# Altium schematic sheet Remote controller 1023.SchDoc
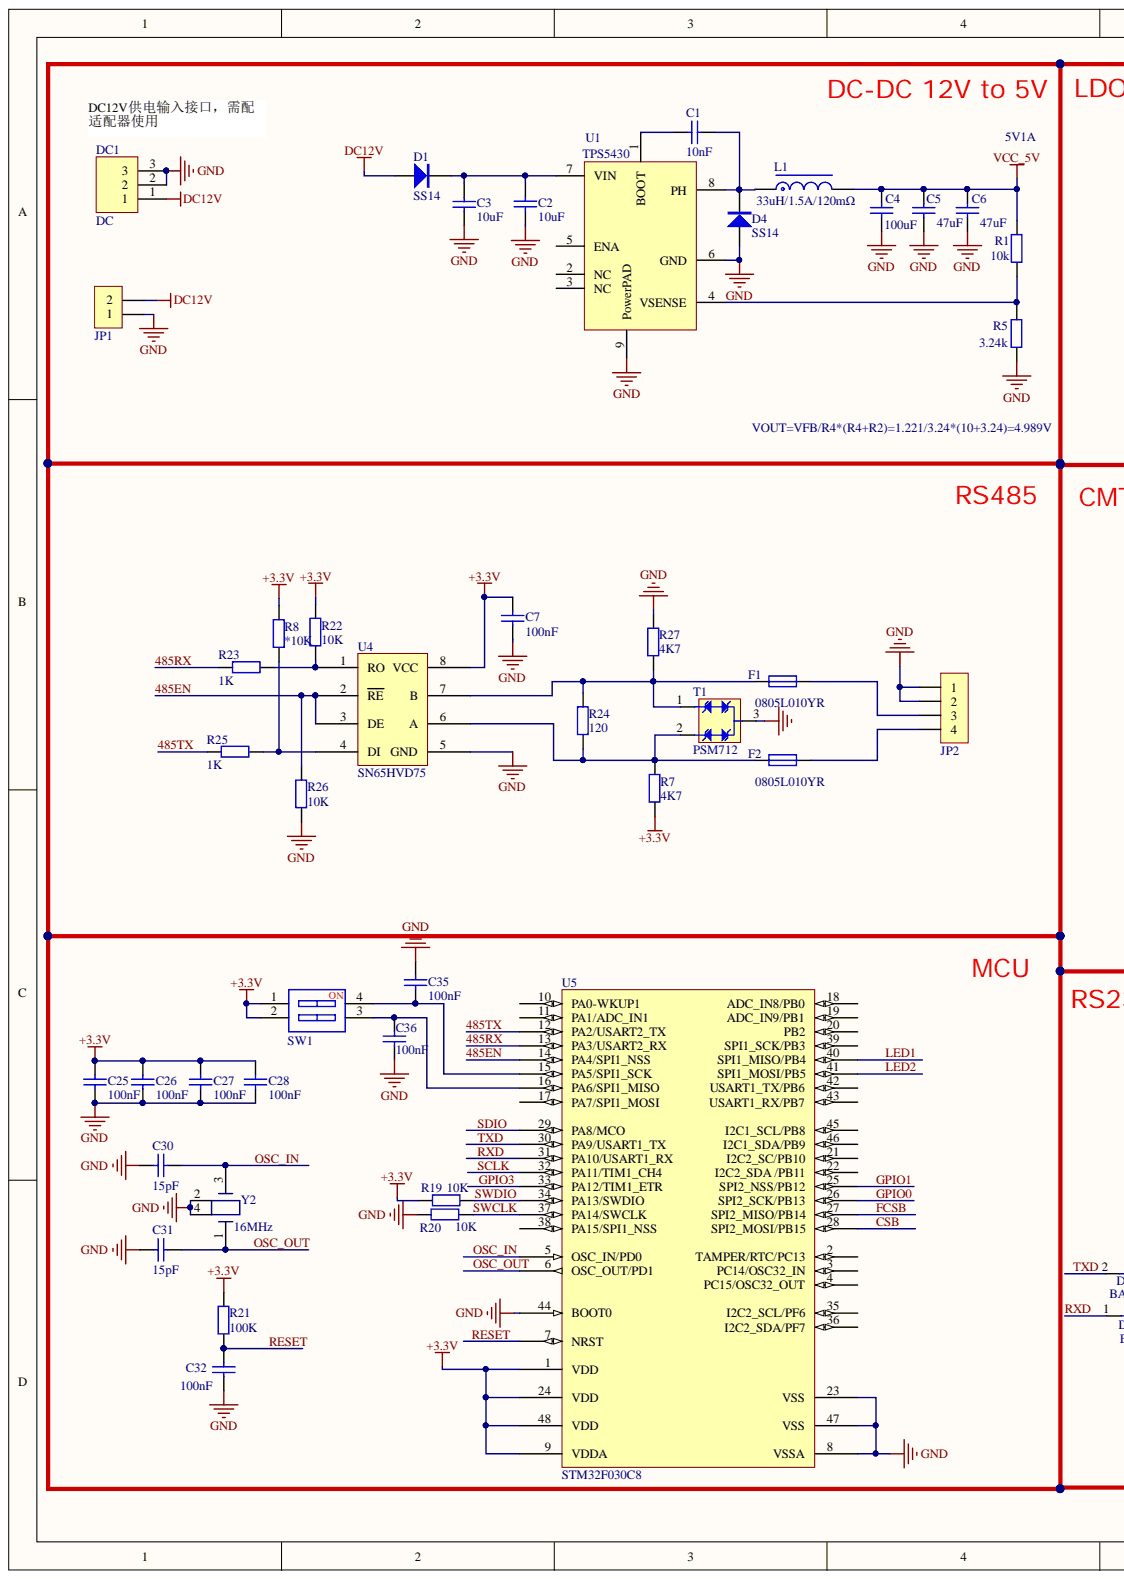
[diagram p0: Remote controller 1023.SchDoc, left]
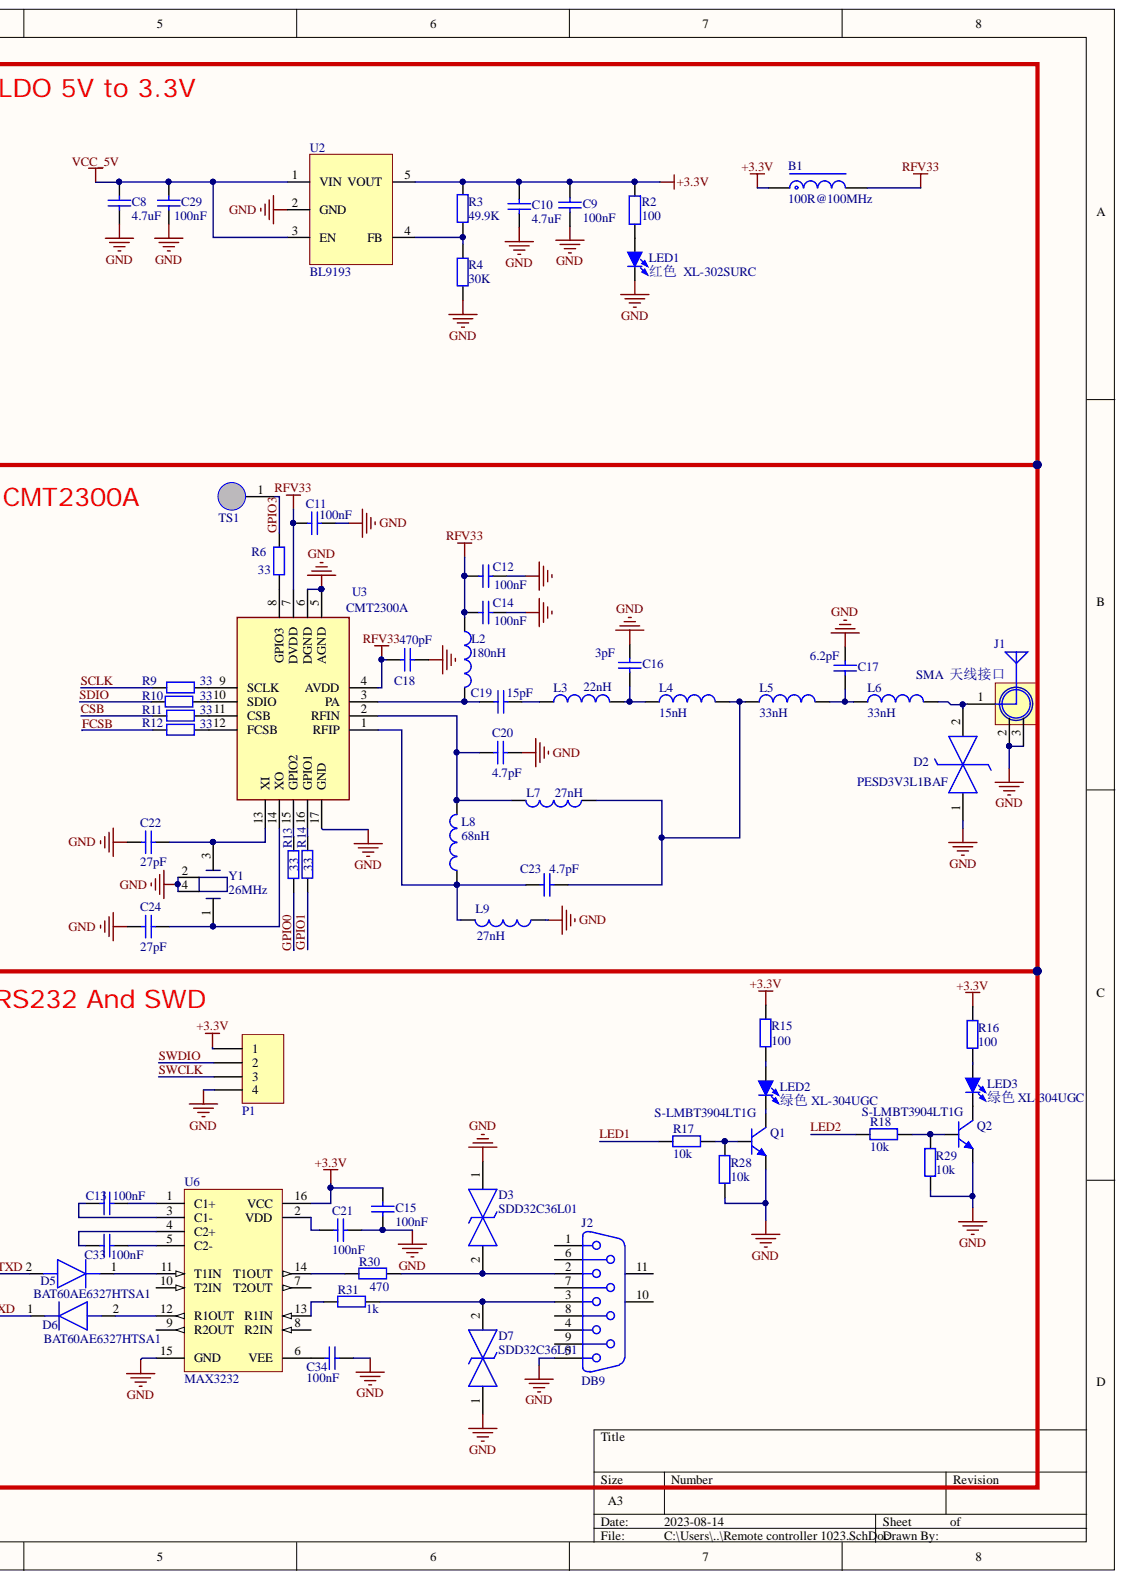
[diagram p1: Remote controller 1023.SchDoc, right]

## Parts
B1  100R@100MHz  [C 0603]
C1  10nF  [C 0603]
C2  10uF  [C1206_M]
C3  10uF  [C1206_M]
C4  100uF  [C1210_M]
C5  47uF  [C1210_M]
C6  47uF  [C1210_M]
C7  100nF  [C 0603]
C8  4.7uF  [C 0603]
C9  100nF  [C 0603]
C10  4.7uF  [C 0603]
C11  100nF  [C 0603]
C12  100nF  [C 0603]
C13  100nF  [C 0603]
C14  100nF  [C 0603]
C15  100nF  [C 0603]
C16  3pF  [C 0603]
C17  6.2pF  [C 0603]
C18  470pF  [C 0603]
C19  15pF  [C 0603]
C20  4.7pF  [C 0603]
C21  100nF  [C 0603]
C22  27pF  [C 0603]
C23  4.7pF  [C 0603]
C24  27pF  [C 0603]
C25  100nF  [C 0603]
C26  100nF  [C 0603]
C27  100nF  [C 0603]
C28  100nF  [C 0603]
C29  100nF  [C 0603]
C30  15pF  [C 0603]
C31  15pF  [C 0603]
C32  100nF  [C 0603]
C33  100nF  [C 0603]
C34  100nF  [C 0603]
C35  100nF  [C 0603]
C36  100nF  [C 0603]
D1  SS14  [SMA]
D2  PESD3V3L1BAF  [SOD2512X110N]
D3  SDD32C36L01  [SOD2612X120N]
D4  SS14  [SMA]
D5  BAT60AE6327HTSA1  [SOD2513X110N]
D6  BAT60AE6327HTSA1  [SOD2513X110N]
D7  SDD32C36L01  [SOD2612X120N]
DC1  DC  [DC-005]
F1  0805L010YR  [R0805_M]
F2  0805L010YR  [R0805_M]
J1  SMA  天线接口  [SMA_FR]
J2  DB9  [DB9/S_A]
JP1  HDR-1X2  [KF2EDGK5.08-WI-2P]  (value not drawn)
JP2  HDR-1X4  [KF2EDGK5.08-WI-4P]  (value not drawn)
L1  33uH/1.5A/120mΩ  [CD75]
L2  180nH  [C 0603]
L3  22nH  [C 0603]
L4  15nH  [C 0603]
L5  33nH  [C 0603]
L6  33nH  [C 0603]
L7  27nH  [C 0603]
L8  68nH  [C 0603]
L9  27nH  [C 0603]
LED1  红色  XL-302SURC  [LED 3MM-R]
LED2  绿色 XL-304UGC  [LED 3MM-G]
LED3  绿色 XL-304UGC  [LED 3MM-G]
P1  Header 4  [HDR1X4]  (value not drawn)
Q1  S-LMBT3904LT1G  [SOT23-3N]
Q2  S-LMBT3904LT1G  [SOT23-3N]
R1  10k  [C 0603]
R2  100  [C 0603]
R3  49.9K  [C 0603]
R4  30K  [C 0603]
R5  3.24k  [C 0603]
R6  33  [C 0603]
R7  value 4K7  [R0603_M]  (hidden comment: 1k)
R8  value *10K  [R0603_M]  (hidden comment: 1k)
R9  33  [C 0603]
R10  33  [C 0603]
R11  33  [C 0603]
R12  33  [C 0603]
R13  33  [C 0603]
R14  33  [C 0603]
R15  100  [C 0603]
R16  100  [C 0603]
R17  10k  [C 0603]
R18  10k  [C 0603]
R19  10K  [C 0603]
R20  10K  [C 0603]
R21  100K  [C 0603]
R22  value 10K  [R0603_M]  (hidden comment: 1k)
R23  1k  [R0603_M]
R24  value 120  [R0603_M]  (hidden comment: 1k)
R25  1k  [R0603_M]
R26  value 10K  [R0603_M]  (hidden comment: 1k)
R27  value 4K7  [R0603_M]  (hidden comment: 1k)
R28  10k  [C 0603]
R29  10k  [C 0603]
R30  470  [C 0603]
R31  1k  [C 0603]
SW1  DIP-SW-2P  [SW DIP-2P]  (value not drawn)
T1  PSM712  [SOT23-3M]
TS1  12x16  [TEST 1.2]  (value not drawn)
U1  TPS5430  [TPS5430]
U2  BL9193  [SOT23-5 - duplicate]
U3  CMT2300A  [QFN50P300X300X80-17N-D]
U4  SN65HVD75  [SOP8W_M]
U5  STM32F030C8  [LQFP48 7X7_N]
U6  MAX3232  [SOP16_M]
Y1  26MHz  [XTAL-3225]
Y2  16MHz  [XTAL-3225]

## Nets
+3.3V: B1.1 C7.2 C9.2 C10.2 C15.2 C25.2 C26.2 C27.2 C28.2 P1.1 R2.2 R3.2 R7.1 R8.2 R15.2 R16.2 R19.1 R21.2 R22.2 SW1.1 SW1.2 U2.5(VOUT) U4.8(VCC) U5.1(VDD) U5.9(VDDA) U5.24(VDD) U5.48(VDD) U6.16(VCC)
DC12V: D1.A DC1.1 JP1.2
GND: C2.1 C3.1 C4.1 C5.1 C6.1 C7.1 C8.1 C9.1 C10.1 C11.2 C12.1 C14.1 C15.1 C16.1 C17.1 C18.1 C20.1 C21.2 C22.2 C24.2 C25.1 C26.1 C27.1 C28.1 C29.1 C30.2 C31.2 C32.1 C34.1 C35.1 C36.1 D2.1 D3.1 D4.A D7.1 DC1.2 DC1.3 J1.2 J1.3 J2.5 JP1.1 JP2.1 JP2.2 L9.1 LED1.2 P1.4 Q1.2 Q2.2 R4.1 R5.1 R20.1 R26.1 R27.2 R28.2 R29.2 T1.3 U1.6(GND) U1.9(PowerPAD) U2.2(GND) U3.5(AGND) U3.6(DGND) U3.17(GND) U4.5(GND) U5.8(VSSA) U5.23(VSS) U5.44(BOOT0) U5.47(VSS) U6.15(GND) Y1.2 Y1.4 Y2.2 Y2.4
RFV33: B1.2 C11.1 C12.2 C14.2 C18.2 L2.1 U3.4(AVDD) U3.7(DVDD)
VCC_5V: C4.2 C5.2 C6.2 C8.2 C29.2 L1.2 R1.1 U2.1(VIN) U2.3(EN)
485EN: R26.2 U4.2(RE) U4.3(DE) U5.14(PA4/SPI1_NSS)
485RX: R23.1 U5.13(PA3/USART2_RX)
485TX: R25.1 U5.12(PA2/USART2_TX)
CSB: R11.1 U5.28(SPI2_MOSI/PB15)
FCSB: R12.1 U5.27(SPI2_MISO/PB14)
GPIO0: R13.2 U5.26(SPI2_SCK/PB13)
GPIO1: R14.2 U5.25(SPI2_NSS/PB12)
GPIO3: R6.1 TS1.1 U5.33(PA12/TIM1_ETR)
LED1: R17.2 U5.40(SPI1_MISO/PB4)
LED2: R18.2 U5.41(SPI1_MOSI/PB5)
OSC_IN: C30.1 U5.5(OSC_IN/PD0) Y2.3
OSC_OUT: C31.1 U5.6(OSC_OUT/PD1) Y2.1
RESET: C32.2 R21.1 U5.7(NRST)
RXD: D6.1 U5.31(PA10/USART1_RX)
SCLK: R9.1 U5.32(PA11/TIM1_CH4)
SDIO: R10.1 U5.29(PA8/MCO)
SWCLK: P1.3 R20.2 U5.37(PA14/SWCLK)
SWDIO: P1.2 R19.2 U5.34(PA13/SWDIO)
TXD: D5.2 U5.30(PA9/USART1_TX)
NetC1_1: C1.1 D4.K L1.1 U1.8(PH)
NetC1_2: C1.2 U1.1(BOOT)
NetC2_2: C2.2 C3.2 D1.K U1.7(VIN)
NetC13_1: C13.1 U6.1(C1+)
NetC13_2: C13.2 U6.3(C1-)
NetC16_2: C16.2 L3.2 L4.1
NetC17_2: C17.2 L5.2 L6.1
NetC19_1: C19.1 L3.1
NetC19_2: C19.2 L2.2 U3.3(PA)
NetC20_2: C20.2 L7.2 L8.2 U3.2(RFIN)
NetC21_1: C21.1 U6.2(VDD)
NetC22_1: C22.1 U3.13(XI) Y1.3
NetC23_1: C23.1 L4.2 L5.1 L7.1
NetC23_2: C23.2 L8.1 L9.2 U3.1(RFIP)
NetC24_1: C24.1 U3.14(XO) Y1.1
NetC33_1: C33.1 U6.5(C2-)
NetC33_2: C33.2 U6.4(C2+)
NetC34_2: C34.2 U6.6(VEE)
NetC35_2: C35.2 SW1.4 U5.15(PA5/SPI1_SCK)
NetC36_2: C36.2 SW1.3 U5.16(PA6/SPI1_MISO)
NetD2_2: D2.2 J1.1 L6.2
NetD3_2: D3.2 J2.2 R30.1
NetD5_1: D5.1 U6.11(T1IN)
NetD6_2: D6.2 U6.12(R1OUT)
NetD7_2: D7.2 J2.3 R31.1
NetF1_1: F1.1 R24.2 R27.1 T1.1 U4.7(B)
NetF1_2: F1.2 JP2.3
NetF2_1: F2.1 R7.2 R24.1 T1.2 U4.6(A)
NetF2_2: F2.2 JP2.4
NetLED1_1: LED1.1 R2.1
NetLED2_1: LED2.1 R15.1
NetLED2_2: LED2.2 Q1.3
NetLED3_1: LED3.1 R16.1
NetLED3_2: LED3.2 Q2.3
NetQ1_1: Q1.1 R17.1 R28.1
NetQ2_1: Q2.1 R18.1 R29.1
NetR1_2: R1.2 R5.2 U1.4(VSENSE)
NetR3_1: R3.1 R4.2 U2.4(FB)
NetR6_2: R6.2 U3.8(GPIO3)
NetR8_1: R8.1 R25.2 U4.4(DI)
NetR9_2: R9.2 U3.9(SCLK)
NetR10_2: R10.2 U3.10(SDIO)
NetR11_2: R11.2 U3.11(CSB)
NetR12_2: R12.2 U3.12(FCSB)
NetR13_1: R13.1 U3.15(GPIO2)
NetR14_1: R14.1 U3.16(GPIO1)
NetR22_1: R22.1 R23.2 U4.1(RO)
NetR30_2: R30.2 U6.14(T1OUT)
NetR31_2: R31.2 U6.13(R1IN)
N00014:

Not connected: J2 1 4 6 7 8 9 10 11; U1 2(NC) 3(NC) 5(ENA); U5 2(TAMPER/RTC/PC13) 3(PC14/OSC32_IN) 4(PC15/OSC32_OUT) 10(PA0-WKUP1) 11(PA1/ADC_IN1) 17(PA7/SPI1_MOSI) 18(ADC_IN8/PB0) 19(ADC_IN9/PB1) 20(PB2) 21(I2C2_SC/PB10) 22(I2C2_SDA_/PB11) 35(I2C2_SCL/PF6) 36(I2C2_SDA/PF7) 38(PA15/SPI1_NSS) 39(SPI1_SCK/PB3) 42(USART1_TX/PB6) 43(USART1_RX/PB7) 45(I2C1_SCL/PB8) 46(I2C1_SDA/PB9); U6 7(T2OUT) 8(R2IN) 9(R2OUT) 10(T2IN)

## Library data of the parts
B1 = FB: 磁珠; BOM名称: 直插磁珠; 联系卖家，免费查找封装: 唯一出售店铺网址https://shop463601444.taobao.com; Component Kind: Standard; Library Name: 0.1 - 电阻-电容-电感-晶振-晶体-磁珠-电位器-法拉电容-馈通电容.SchLib
C1-C7 C9 C11-C36 = Cap: 电容; Component Kind: Standard; Library Name: Schlib.SchLib
C8 C10 = CAP 0402: Component Kind: Standard; Library Name: Schlib.SchLib
D1 D4 = SS14: 肖特基二极管; 属性: 40V/1A; 版权归属: 源创客; BOM名称: 肖特基二极管; Component Kind: Standard; Library Name: 二极管-整流桥.SchLib; 学习资源: www.ooocke.com
D2 = PESD5V0S1BA,115: Diode ESD (Bi-directional); Manufacturer_Name: Nexperia; Arrow Part Number: PESD5V0S1BA,115; Arrow Price/Stock: https://www.arrow.com/en/products/pesd5v0s1ba115/nexperia?region=europe; Component Kind: Standard; Datasheet Link: https://assets.nexperia.com/documents/data-sheet/PESD5V0S1BA.pdf; Height: 1.1mm; Manufacturer_Part_Number: PESD5V0S1BA,115; Mouser Part Number: 771-PESD5V0S1BA-T/R; Mouser Price/Stock: https://www.mouser.co.uk/ProductDetail/Nexperia/PESD5V0S1BA115?qs=LOCUfHb8d9sN8BP26arEXQ%3D%3D
D3 D7 = SDD32C36L01: TVS Diode (Bi-directional); Manufacturer_Name: KEMET; Component Kind: Standard; Datasheet Link: https://www.yageo.com/upload/media/product/productsearch/datasheet/cpc/esd/SDD32CXXL01_1.pdf; Height: 1.2mm; Manufacturer_Part_Number: SDD32C36L01
D5 D6 = BAT60AE6327HTSA1: Diode; Manufacturer_Name: Infineon; Arrow Part Number: BAT60AE6327HTSA1; Arrow Price/Stock: https://www.arrow.com/en/products/bat60ae6327htsa1/infineon-technologies-ag?region=nac; Component Kind: Standard; Datasheet Link: https://www.infineon.com/dgdl/Infineon-BAT60ASERIES-DS-v01_01-en.pdf?fileId=db3a304313d846880113def70c9304a9; Height: 1.1mm; Manufacturer_Part_Number: BAT60AE6327HTSA1; Mouser Part Number: 726-BAT60AE6327HTSA1; Mouser Price/Stock: https://www.mouser.co.uk/ProductDetail/Infineon-Technologies/BAT60AE6327HTSA1?qs=OwbwYO03UsIW3Y2lCXbs8Q%3D%3D
DC1 = DC3: 3脚DC接口; Component Kind: Standard; Library Name: Schlib.SchLib
F1 F2 = FUSE: Resistor; Component Kind: Standard; LatestRevisionDate: 17-Jul-2002; LatestRevisionNote: Re-released for DXP Platform.; Library Name: Sch.SchLib; PackageDescription: Axial Device, Thru-Hole; 2 Leads; 0.4 in Pin Spacing; PackageReference: AXIAL-0.4; Published: 8-Jun-2000; Signal Integrity: Res; Simulation: RESISTOR; Publisher: Altium Limited
J1 = SMA: SMA-2.4G射频天线接口; 联系卖家，免费技术支持: 唯一出售店铺网址https://shop463601444.taobao.com; BOM名称: SMA-2.4G射频天线接口; Component Kind: Standard; Library Name: 1.7 - WiFi天线-SMA射频座.SchLib
J2 = DB9: 9针串口; 联系卖家 免费技术支持: 封装库来自淘宝卖家ID：黄晶宝石; BOM名称: 9针串口; Component Kind: Standard; Library Name: 0.6 - 电源_RJ45以太网_音频_SD-TF_SIM_ USB Type-C_HDMI插座.SchLib
JP1 = Header 2: 2P接插件; 联系卖家，免费技术支持: 唯一出售店铺网址https://shop463601444.taobao.com; BOM名称: 2P接插件; Component Kind: Standard; Library Name: 6.1 - 5.08mm连接器(KF2EDGK5.08).SchLib
JP2 = Header 4: 4P接插件; 联系卖家，免费技术支持: 唯一出售店铺网址https://shop463601444.taobao.com; BOM名称: 4P接插件; Component Kind: Standard; Library Name: 6.1 - 5.08mm连接器(KF2EDGK5.08).SchLib
L1 = L-SMD: CD系列贴片线绕功率电感; BOM名称: 功率电感; 联系卖家，免费查找封装: 唯一出售店铺网址https://shop463601444.taobao.com; Component Kind: Standard; Library Name: 0.1 - 电阻-电容-电感-晶振-晶体-磁珠-电位器-法拉电容-馈通电容.SchLib
L2-L9 = IND 0402: Component Kind: Standard; Library Name: Schlib.SchLib
LED1-LED3 = LED-SMD: 贴片LED; 封装库来自淘宝卖家ID：黄晶宝石: 其他店铺购入均为盗版封装库，请立即申请退款，本店现金奖励20~200元; BOM名称: 贴片LED; Component Kind: Standard; Library Name: 0.3 - LED发光二极管-IR二极管_接收管-光耦.SchLib
Q1 Q2 = 8050-SMD: 高频放大-NPN型; 8元以下特价购入的买家: 还可以联系卖家远程指导AD软件使用; 参数: 40V 1.5A 1W 100MHZ; BOM名称: 高频放大-NPN型; Component Kind: Standard; Library Name: 0.4 - 三极管-场效应管-可控硅.SchLib
R1 R3-R6 R9-R21 R28-R31 = Resistor: Resistor SMD - IEC symbol version; Component Kind: Standard; LatestRevisionDate: 27-Jul-20  -  Semtech; Library Name: Schlib.SchLib
R2 = R: 贴片电阻; 联系卖家，免费查找封装: 唯一出售店铺网址https://shop463601444.taobao.com; BOM名称: 贴片电阻; Component Kind: Standard; Library Name: 0.1 - 电阻-电容-电感-晶振-晶体-磁珠-电位器-法拉电容-馈通电容.SchLib
R7 R8 R22-R27 = Res2: Resistor; Component Kind: Standard; LatestRevisionDate: 17-Jul-2002; LatestRevisionNote: Re-released for DXP Platform.; Library Name: 0.1 - 电阻-电容-电感-晶振-晶体-磁珠-电位器-法拉电容-馈通电容.SchLib; PackageDescription: Axial Device, Thru-Hole; 2 Leads; 0.4 in Pin Spacing; PackageReference: AXIAL-0.4; Published: 8-Jun-2000; Signal Integrity: Res; Simulation: RESISTOR; Publisher: Altium Limited
  R23 R25: Value: 1K
SW1 = DIP-SW-2P: 2路编码开关; 封装库来自淘宝卖家ID：黄晶宝石: 其他店铺购入的，请立即申请退款，本店现金奖励20~500元; 联系卖家，免费查找封装: 唯一出售店铺网址https://shop463601444.taobao.com; BOM名称: 2路编码开关; Component Kind: Standard; Library Name: 0.5 - 按键_开关_蜂鸣器_保险丝_电池_测试点_Mark点_铜柱.SchLib
TS1 = TTS: 触控弹簧; 封装库来自淘宝卖家ID：黄晶宝石: 其他店铺购入的，请立即申请退款，本店现金奖励20~500元; 联系卖家，免费查找封装: 唯一出售店铺网址https://shop463601444.taobao.com; BOM名称: 触控弹簧; Component Kind: Standard; Library Name: 0.5 - 按键_开关_蜂鸣器_保险丝_电池_测试点_Mark点_铜柱.SchLib
U2 = BL9193: LDO; Component Kind: Standard; Library Name: Schlib.SchLib
U3 = CMT2300A: Undefined or Miscellaneous; Manufacturer_Name: HOPERF; Component Kind: Standard; Datasheet Link: http://www.cmostek.com/download/CMT2300A-CN-Rev0.7.pdf; Height: 0.8mm; Manufacturer_Part_Number: CMT2300A
U4 = MAX485: Component Kind: Standard; Library Name: PINE-SCH.SCHLIB
U5 = STM32F030C8: Flaah:64/RAM:8/IO:39; 联系卖家，免费查找封装: 唯一出售店铺网址https://shop463601444.taobao.com; Component Kind: Standard; Library Name: 2.3 - STM32 F0系列单片机.SchLib
U6 = MAX232: +5V Powered, Multi-Channel RS-232 Driver/Receiver; 联系卖家 免费查找封装: 唯一出售店铺网址https://shop463601444.taobao.com; Component Kind: Standard; Library Name: 0.8 - 常见芯片.SchLib
Y1 Y2 = Oscillator_4: Component Kind: Standard; Library Name: Schlib.SchLib
P1 = Header 4: Component Kind: Standard; Library Name: Schlib.SchLib
T1 = PSM712: Component Kind: Standard; Library Name: VERSACE.SchLib
U1 = TPS5430: Component Kind: Standard; Library Name: Schlib.SchLib
